# Altium schematic sheet 888原理图V1-2.SchDoc
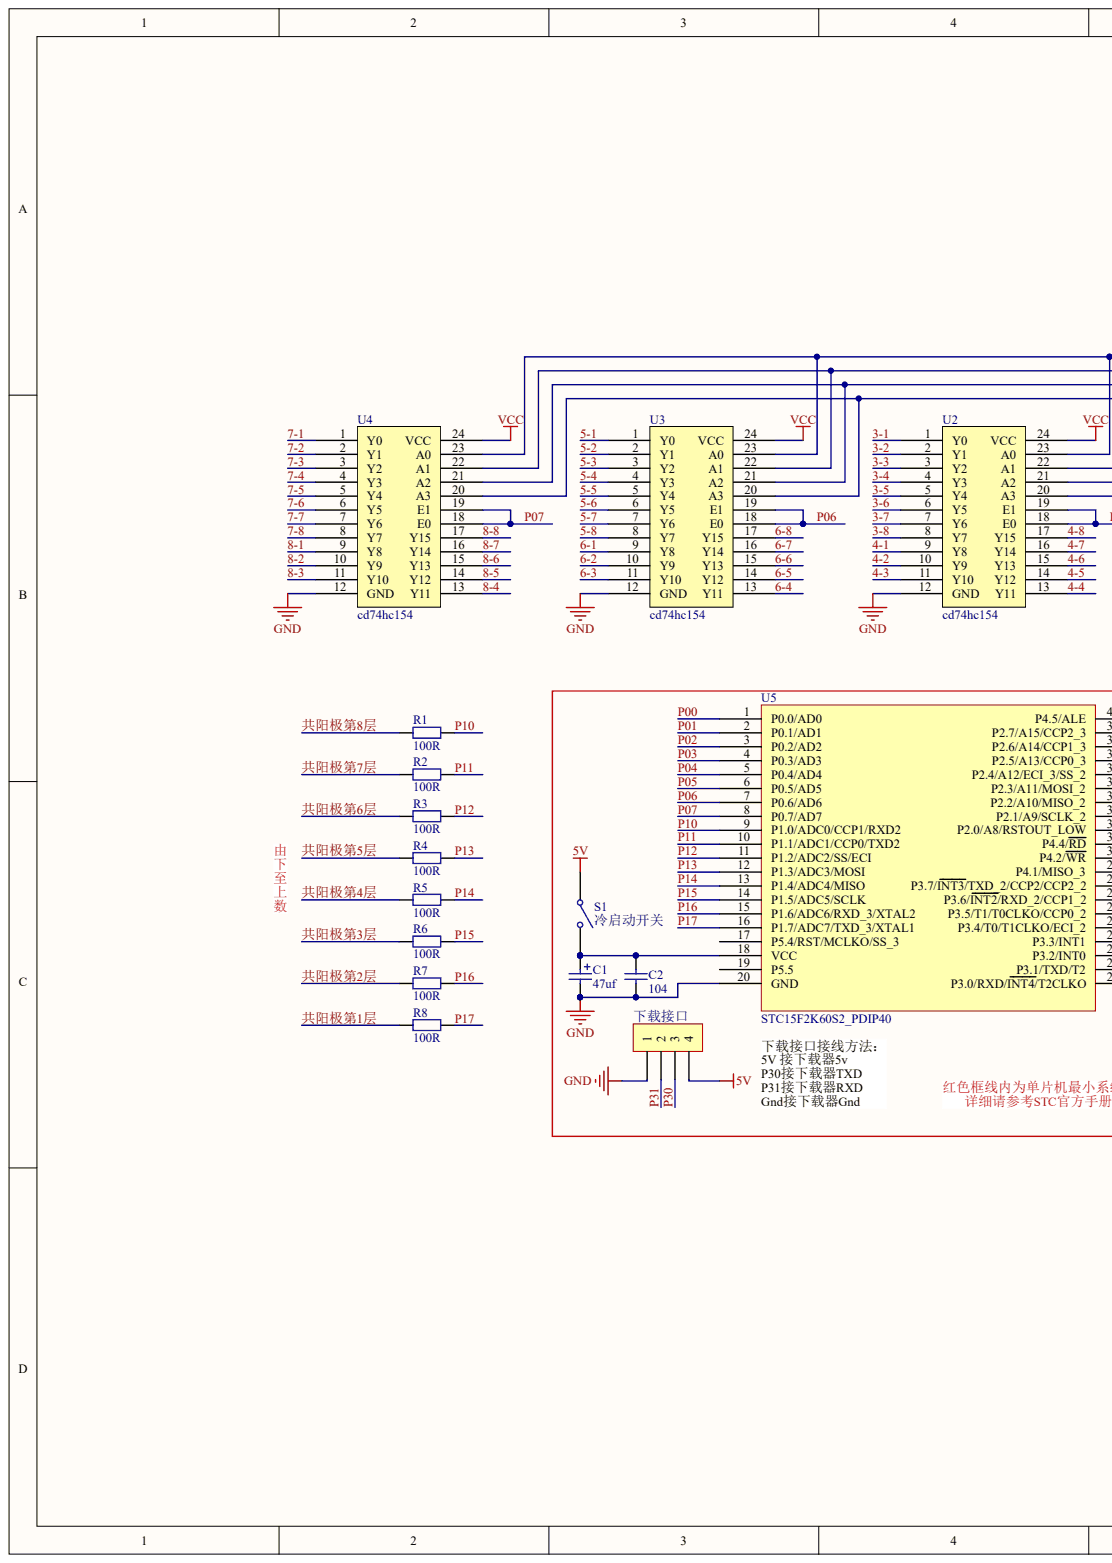
[diagram p0: 888原理图V1-2.SchDoc, left]
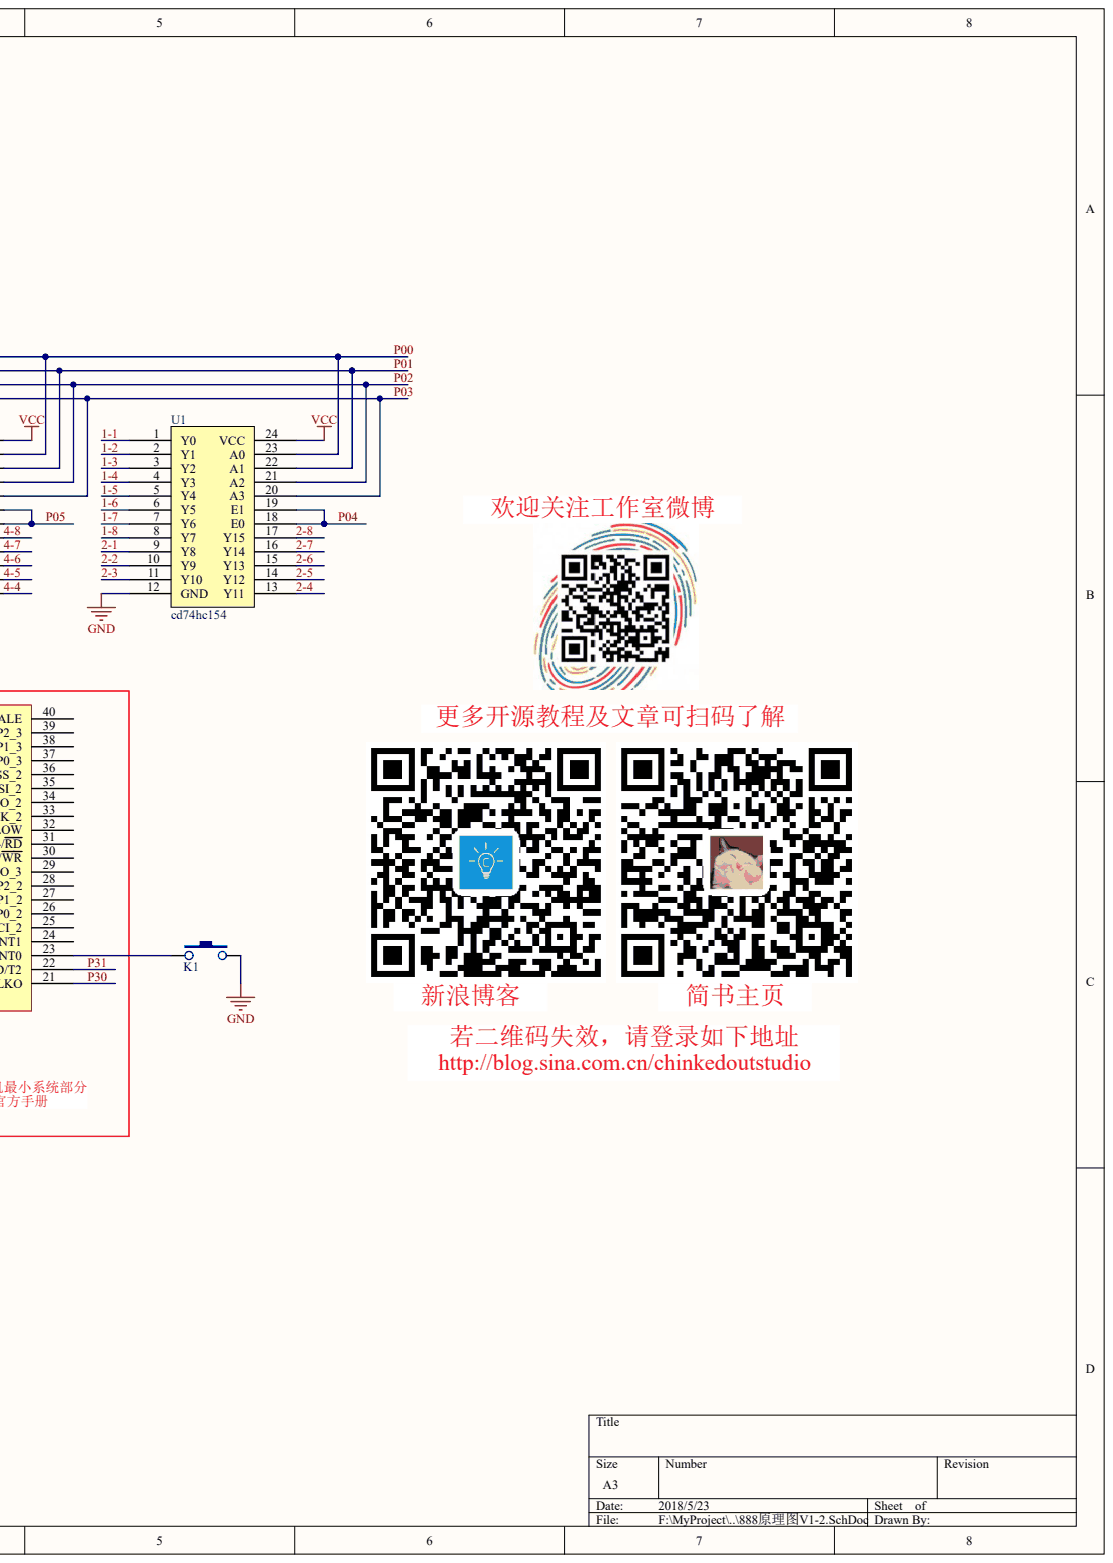
[diagram p1: 888原理图V1-2.SchDoc, right]

## Parts
C1  value 47uf  (hidden comment: Cap Pol2)
C2  104
K1  BUTTON  (value not drawn)
R1  value 100R  (hidden comment: Res2)
R2  value 100R  (hidden comment: Res2)
R3  value 100R  (hidden comment: Res2)
R4  value 100R  (hidden comment: Res2)
R5  value 100R  (hidden comment: Res2)
R6  value 100R  (hidden comment: Res2)
R7  value 100R  (hidden comment: Res2)
R8  value 100R  (hidden comment: Res2)
S1  冷启动开关
U1  cd74hc154
U2  cd74hc154
U3  cd74hc154
U4  cd74hc154
U5  STC15F2K60S2_PDIP40
下载接口  (no value)

## Nets
5V: S1.1 下载接口.4
GND: C1.2 C2.1 K1.2 U1.12(GND) U2.12(GND) U3.12(GND) U4.12(GND) U5.20(GND) 下载接口.1
VCC: U1.24(VCC) U2.24(VCC) U3.24(VCC) U4.24(VCC)
1-1: U1.1(Y0)
1-2: U1.2(Y1)
1-3: U1.3(Y2)
1-4: U1.4(Y3)
1-5: U1.5(Y4)
1-6: U1.6(Y5)
1-7: U1.7(Y6)
1-8: U1.8(Y7)
2-1: U1.9(Y8)
2-2: U1.10(Y9)
2-3: U1.11(Y10)
2-4: U1.13(Y11)
2-5: U1.14(Y12)
2-6: U1.15(Y13)
2-7: U1.16(Y14)
2-8: U1.17(Y15)
3-1: U2.1(Y0)
3-2: U2.2(Y1)
3-3: U2.3(Y2)
3-4: U2.4(Y3)
3-5: U2.5(Y4)
3-6: U2.6(Y5)
3-7: U2.7(Y6)
3-8: U2.8(Y7)
4-1: U2.9(Y8)
4-2: U2.10(Y9)
4-3: U2.11(Y10)
4-4: U2.13(Y11)
4-5: U2.14(Y12)
4-6: U2.15(Y13)
4-7: U2.16(Y14)
4-8: U2.17(Y15)
5-1: U3.1(Y0)
5-2: U3.2(Y1)
5-3: U3.3(Y2)
5-4: U3.4(Y3)
5-5: U3.5(Y4)
5-6: U3.6(Y5)
5-7: U3.7(Y6)
5-8: U3.8(Y7)
6-1: U3.9(Y8)
6-2: U3.10(Y9)
6-3: U3.11(Y10)
6-4: U3.13(Y11)
6-5: U3.14(Y12)
6-6: U3.15(Y13)
6-7: U3.16(Y14)
6-8: U3.17(Y15)
7-1: U4.1(Y0)
7-2: U4.2(Y1)
7-3: U4.3(Y2)
7-4: U4.4(Y3)
7-5: U4.5(Y4)
7-6: U4.6(Y5)
7-7: U4.7(Y6)
7-8: U4.8(Y7)
8-1: U4.9(Y8)
8-2: U4.10(Y9)
8-3: U4.11(Y10)
8-4: U4.13(Y11)
8-5: U4.14(Y12)
8-6: U4.15(Y13)
8-7: U4.16(Y14)
8-8: U4.17(Y15)
P00: U1.23(A0) U2.23(A0) U3.23(A0) U4.23(A0) U5.1(P0.0/AD0)
P01: U1.22(A1) U2.22(A1) U3.22(A1) U4.22(A1) U5.2(P0.1/AD1)
P02: U1.21(A2) U2.21(A2) U3.21(A2) U4.21(A2) U5.3(P0.2/AD2)
P03: U1.20(A3) U2.20(A3) U3.20(A3) U4.20(A3) U5.4(P0.3/AD3)
P04: U1.18(E0) U1.19(E1) U5.5(P0.4/AD4)
P05: U2.18(E0) U2.19(E1) U5.6(P0.5/AD5)
P06: U3.18(E0) U3.19(E1) U5.7(P0.6/AD6)
P07: U4.18(E0) U4.19(E1) U5.8(P0.7/AD7)
P10: R1.2 U5.9(P1.0/ADC0/CCP1/RXD2)
P11: R2.2 U5.10(P1.1/ADC1/CCP0/TXD2)
P12: R3.2 U5.11(P1.2/ADC2/SS/ECI)
P13: R4.2 U5.12(P1.3/ADC3/MOSI)
P14: R5.2 U5.13(P1.4/ADC4/MISO)
P15: R6.2 U5.14(P1.5/ADC5/SCLK)
P16: R7.2 U5.15(P1.6/ADC6/RXD_3/XTAL2)
P17: R8.2 U5.16(P1.7/ADC7/TXD_3/XTAL1)
P30: U5.21(P3.0/RXD/INT4/T2CLKO) 下载接口.3
P31: U5.22(P3.1/TXD/T2) 下载接口.2
共阳极第2层: R7.1
共阳极第3层: R6.1
共阳极第4层: R5.1
共阳极第5层: R4.1
共阳极第6层: R3.1
共阳极第7层: R2.1
共阳极第8层: R1.1 R8.1
NetC1_1: C1.1 C2.2 S1.2 U5.18(VCC)
NetK1_1: K1.1 U5.23(P3.2/INT0)

Not connected: U5 17(P5.4/RST/MCLKO/SS_3) 19(P5.5) 24(P3.3/INT1) 25(P3.4/T0/T1CLKO/ECI_2) 26(P3.5/T1/T0CLKO/CCP0_2) 27(P3.6/INT2/RXD_2/CCP1_2) 28(P3.7/INT3/TXD_2/CCP2/CCP2_2) 29(P4.1/MISO_3) 30(P4.2/WR) 31(P4.4/RD) 32(P2.0/A8/RSTOUT_LOW) 33(P2.1/A9/SCLK_2) 34(P2.2/A10/MISO_2) 35(P2.3/A11/MOSI_2) 36(P2.4/A12/ECI_3/SS_2) 37(P2.5/A13/CCP0_3) 38(P2.6/A14/CCP1_3) 39(P2.7/A15/CCP2_3) 40(P4.5/ALE)

## Library data of the parts
C1 C2 K1 R1-R8 S1 U1-U5 下载接口 = (no symbol name)
  C1: Published: 8-Jun-2000; LatestRevisionDate: 17-Jul-2002; LatestRevisionNote: Re-released for DXP Platform.; PackageReference: POLAR0.8; PackageDescription: Polarized Capacitor; 2 Leads
  C2: Published: 8-Jun-2000; LatestRevisionDate: 17-Jul-2002; LatestRevisionNote: Re-released for DXP Platform.; PackageReference: RAD-0.3; PackageDescription: Radial Cap, Thru-Hole; 2 Leads; 0.3 in Pin Spacing; Value: 104
  R1-R8: Published: 8-Jun-2000; LatestRevisionDate: 17-Jul-2002; LatestRevisionNote: Re-released for DXP Platform.; PackageReference: AXIAL-0.4; PackageDescription: Axial Device, Thru-Hole; 2 Leads; 0.4 in Pin Spacing
  S1: Published: 24-Mar-1999; LatestRevisionDate: 17-Jul-2002; LatestRevisionNote: Re-released for DXP Platform.; PackageReference: SPST-2; PackageDescription: Switch; 2 Leads
  C1 C2 R1-R8 S1: Publisher: Altium Limited
